# Altium schematic sheet CONNECTORS.SchDoc
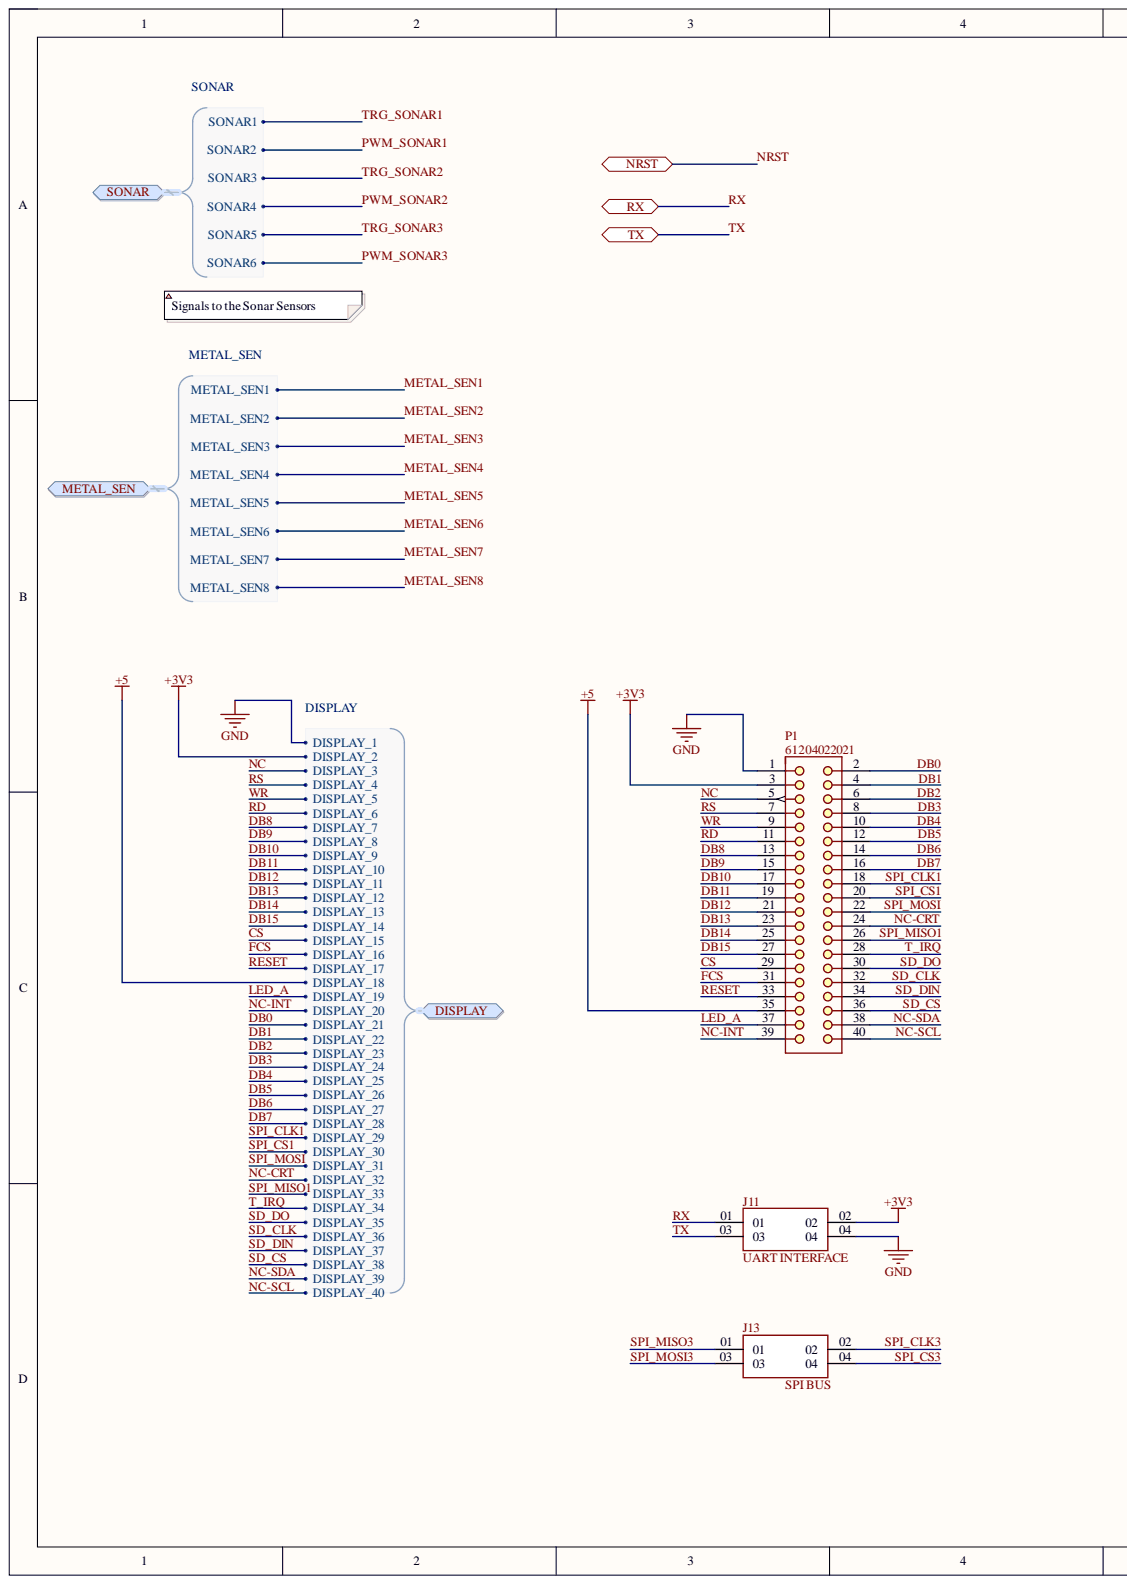
[diagram p0: CONNECTORS.SchDoc, left]
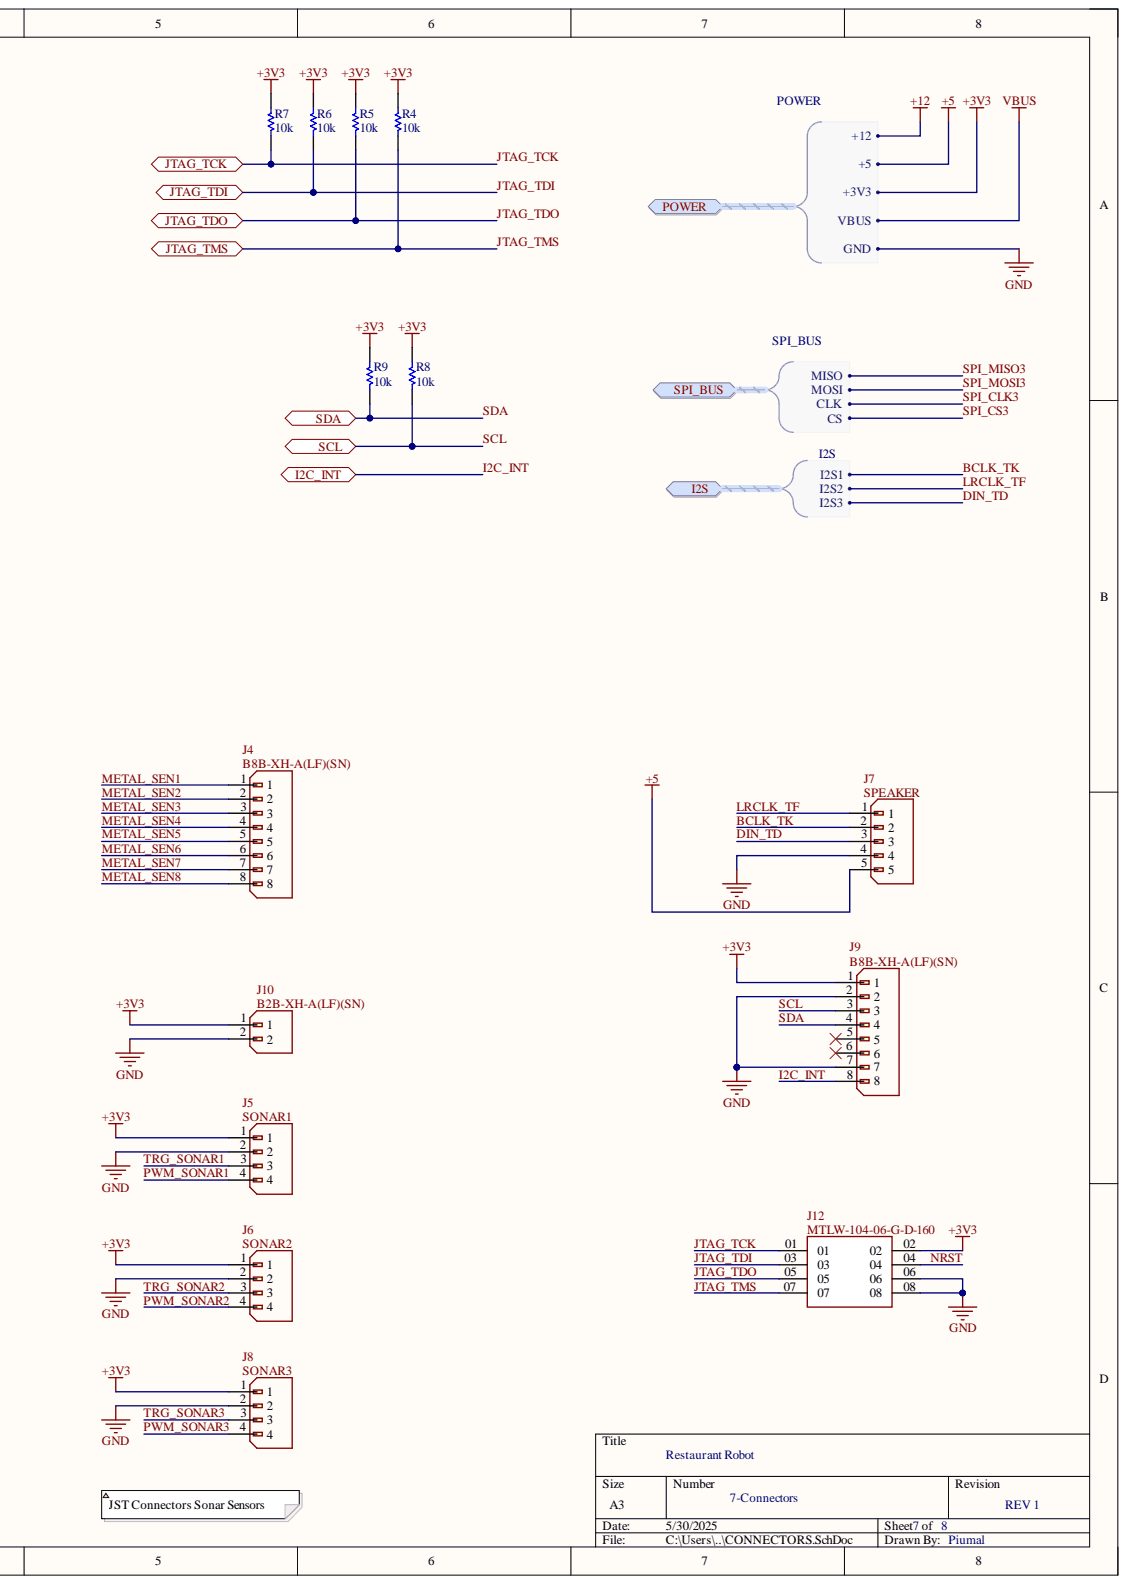
[diagram p1: CONNECTORS.SchDoc, right]

## Parts
J4  B8B-XH-A(LF)(SN)  [JST_B8B-XH-A(LF)(SN)]
J5  SONAR1  [JST_B4B-XH-A(LF)(SN)]
J6  SONAR2  [JST_B4B-XH-A(LF)(SN)]
J7  SPEAKER  [JST_B5B-XH-A(LF)(SN)]
J8  SONAR3  [JST_B4B-XH-A(LF)(SN)]
J9  B8B-XH-A(LF)(SN)  [JST_B8B-XH-A(LF)(SN)]
J10  B2B-XH-A(LF)(SN)  [JST_B2B-XH-A(LF)(SN)]
J11  UART INTERFACE  [SAMTEC_MTLW-102-XX-X-D-XXX]
J12  MTLW-104-06-G-D-160  [SAMTEC_MTLW-104-06-G-D-160]
J13  value SPI BUS  [SAMTEC_MTLW-102-XX-X-D-XXX]  (hidden comment: MTLW-102-XX-X-D-XXX)
P1  61204022021  [61204022021]
R4  Resistance: 10k  [RESC2013X60X35ML10T20]  (hidden comment: RC0805JR-0710KL)
R5  Resistance: 10k  [RESC2013X60X35ML10T20]  (hidden comment: RC0805JR-0710KL)
R6  Resistance: 10k  [RESC2013X60X35ML10T20]  (hidden comment: RC0805JR-0710KL)
R7  Resistance: 10k  [RESC2013X60X35ML10T20]  (hidden comment: RC0805JR-0710KL)
R8  Resistance: 10k  [RESC2013X60X35ML10T20]  (hidden comment: RC0805JR-0710KL)
R9  Resistance: 10k  [RESC2013X60X35ML10T20]  (hidden comment: RC0805JR-0710KL)

## Ports
DISPLAY, DISPLAY.DISPLAY_1, DISPLAY.DISPLAY_2, DISPLAY.DISPLAY_3, DISPLAY.DISPLAY_4, DISPLAY.DISPLAY_5, DISPLAY.DISPLAY_6, DISPLAY.DISPLAY_7, DISPLAY.DISPLAY_8, DISPLAY.DISPLAY_9, DISPLAY.DISPLAY_10, DISPLAY.DISPLAY_11, DISPLAY.DISPLAY_12, DISPLAY.DISPLAY_13, DISPLAY.DISPLAY_14, DISPLAY.DISPLAY_15, DISPLAY.DISPLAY_16, DISPLAY.DISPLAY_17, DISPLAY.DISPLAY_18, DISPLAY.DISPLAY_19, DISPLAY.DISPLAY_20, DISPLAY.DISPLAY_21, DISPLAY.DISPLAY_22, DISPLAY.DISPLAY_23, DISPLAY.DISPLAY_24, DISPLAY.DISPLAY_25, DISPLAY.DISPLAY_26, DISPLAY.DISPLAY_27, DISPLAY.DISPLAY_28, DISPLAY.DISPLAY_29, DISPLAY.DISPLAY_30, DISPLAY.DISPLAY_31, DISPLAY.DISPLAY_32, DISPLAY.DISPLAY_33, DISPLAY.DISPLAY_34, DISPLAY.DISPLAY_35, DISPLAY.DISPLAY_36, DISPLAY.DISPLAY_37, DISPLAY.DISPLAY_38, DISPLAY.DISPLAY_39, DISPLAY.DISPLAY_40, I2C_INT, I2S, I2S.I2S1, I2S.I2S2, I2S.I2S3, JTAG_TCK, JTAG_TDI, JTAG_TDO, JTAG_TMS, METAL_SEN, METAL_SEN.METAL_SEN1, METAL_SEN.METAL_SEN2, METAL_SEN.METAL_SEN3, METAL_SEN.METAL_SEN4, METAL_SEN.METAL_SEN5, METAL_SEN.METAL_SEN6, METAL_SEN.METAL_SEN7, METAL_SEN.METAL_SEN8, NRST, POWER, POWER.+3V3, POWER.+5, POWER.+12, POWER.GND, POWER.VBUS, RX, SCL, SDA, SONAR, SONAR.SONAR1, SONAR.SONAR2, SONAR.SONAR3, SONAR.SONAR4, SONAR.SONAR5, SONAR.SONAR6, SPI_BUS, SPI_BUS.CLK, SPI_BUS.CS, SPI_BUS.MISO, SPI_BUS.MOSI, TX

## Nets
+3V3: J5.1 J6.1 J8.1 J9.1 J10.1 J11.02 J12.02 P1.3 R4.2 R5.2 R6.2 R7.2 R8.2 R9.2 ; port DISPLAY, port POWER
+5: J7.5 P1.35 ; port DISPLAY, port POWER
BCLK_TK: J7.2 ; port I2S
CS: P1.29 ; port DISPLAY
DB0: P1.2 ; port DISPLAY
DB1: P1.4 ; port DISPLAY
DB2: P1.6 ; port DISPLAY
DB3: P1.8 ; port DISPLAY
DB4: P1.10 ; port DISPLAY
DB5: P1.12 ; port DISPLAY
DB6: P1.14 ; port DISPLAY
DB7: P1.16 ; port DISPLAY
DB8: P1.13 ; port DISPLAY
DB9: P1.15 ; port DISPLAY
DB10: P1.17 ; port DISPLAY
DB11: P1.19 ; port DISPLAY
DB12: P1.21 ; port DISPLAY
DB13: P1.23 ; port DISPLAY
DB14: P1.25 ; port DISPLAY
DB15: P1.27 ; port DISPLAY
DIN_TD: J7.3 ; port I2S
FCS: P1.31 ; port DISPLAY
GND: J5.2 J6.2 J7.4 J8.2 J9.2 J9.7 J10.2 J11.04 J12.06 J12.08 P1.1 ; port DISPLAY, port POWER
I2C_INT: J9.8 ; port I2C_INT
JTAG_TCK: J12.01 R7.1 ; port JTAG_TCK
JTAG_TDI: J12.03 R6.1 ; port JTAG_TDI
JTAG_TDO: J12.05 R5.1 ; port JTAG_TDO
JTAG_TMS: J12.07 R4.1 ; port JTAG_TMS
LED_A: P1.37 ; port DISPLAY
LRCLK_TF: J7.1 ; port I2S
METAL_SEN1: J4.1 ; port METAL_SEN
METAL_SEN2: J4.2 ; port METAL_SEN
METAL_SEN3: J4.3 ; port METAL_SEN
METAL_SEN4: J4.4 ; port METAL_SEN
METAL_SEN5: J4.5 ; port METAL_SEN
METAL_SEN6: J4.6 ; port METAL_SEN
METAL_SEN7: J4.7 ; port METAL_SEN
METAL_SEN8: J4.8 ; port METAL_SEN
NC: P1.5 ; port DISPLAY
NC-CRT: P1.24 ; port DISPLAY
NC-INT: P1.39 ; port DISPLAY
NC-SCL: P1.40 ; port DISPLAY
NC-SDA: P1.38 ; port DISPLAY
NRST: J12.04 ; port NRST
PWM_SONAR1: J5.4 ; port SONAR
PWM_SONAR2: J6.4 ; port SONAR
PWM_SONAR3: J8.4 ; port SONAR
RD: P1.11 ; port DISPLAY
RESET: P1.33 ; port DISPLAY
RS: P1.7 ; port DISPLAY
RX: J11.01 ; port RX
SCL: J9.3 R8.1 ; port SCL
SD_CLK: P1.32 ; port DISPLAY
SD_CS: P1.36 ; port DISPLAY
SD_DIN: P1.34 ; port DISPLAY
SD_DO: P1.30 ; port DISPLAY
SDA: J9.4 R9.1 ; port SDA
SPI_CLK1: P1.18 ; port DISPLAY
SPI_CLK3: J13.02 ; port SPI_BUS
SPI_CS1: P1.20 ; port DISPLAY
SPI_CS3: J13.04 ; port SPI_BUS
SPI_MISO1: P1.26 ; port DISPLAY
SPI_MISO3: J13.01 ; port SPI_BUS
SPI_MOSI: P1.22 ; port DISPLAY
SPI_MOSI3: J13.03 ; port SPI_BUS
T_IRQ: P1.28 ; port DISPLAY
TRG_SONAR1: J5.3 ; port SONAR
TRG_SONAR2: J6.3 ; port SONAR
TRG_SONAR3: J8.3 ; port SONAR
TX: J11.03 ; port TX
WR: P1.9 ; port DISPLAY
NetJ9_5: J9.5
NetJ9_6: J9.6
+12: ; port POWER
VBUS: ; port POWER

## Library data of the parts
J4 J9 = B8B-XH-A(LF)(SN): Connector Header Through Hole 8 position 0.098 (2.50mm); MF: JST Sales America Inc.; Check_prices: https://www.snapeda.com/parts/B8B-XH-A(LF)(SN)/JST+Sales+America+Inc./view-part/?ref=eda; Component Kind: Standard; Library Name: B8B-XH-A_LF__SN_ (1).IntLib; MP: B8B-XH-A(LF)(SN); Package: None; SnapEDA_Link: https://www.snapeda.com/parts/B8B-XH-A(LF)(SN)/JST+Sales+America+Inc./view-part/?ref=snap
J5 J6 J8 = B4B-XH-A(LF)(SN): Connector Header Through Hole 4 position 0.098 (2.50mm); MF: JST Sales; Check_prices: https://www.snapeda.com/parts/B4B-XH-A(LF)(SN)/JST+Sales+America+Inc./view-part/?ref=eda; Component Kind: Standard; Library Name: B4B-XH-A_LF__SN_.IntLib; MP: B4B-XH-A(LF)(SN); Package: None; SnapEDA_Link: https://www.snapeda.com/parts/B4B-XH-A(LF)(SN)/JST+Sales+America+Inc./view-part/?ref=snap
J7 = B5B-XH-A(LF)(SN): Connector Header Through Hole 5 position 0.098 (2.50mm); MF: JST Sales America Inc.; Check_prices: https://www.snapeda.com/parts/B5B-XH-A(LF)(SN)/JST+Sales+America+Inc./view-part/?ref=eda; Component Kind: Standard; Library Name: B5B-XH-A_LF__SN_.IntLib; MP: B5B-XH-A(LF)(SN); Package: None; SnapEDA_Link: https://www.snapeda.com/parts/B5B-XH-A(LF)(SN)/JST+Sales+America+Inc./view-part/?ref=snap
J10 = B2B-XH-A(LF)(SN): Connector Header Through Hole 2 position 0.098 (2.50mm); MF: JST Sales; Check_prices: https://www.snapeda.com/parts/B2B-XH-A(LF)(SN)/JST/view-part/?ref=eda; Component Kind: Standard; Library Name: B2B-XH-A_LF__SN_.IntLib; MP: B2B-XH-A(LF)(SN); Package: None; SnapEDA_Link: https://www.snapeda.com/parts/B2B-XH-A(LF)(SN)/JST/view-part/?ref=snap
J11 J13 = MTLW-102-XX-X-D-XXX: Connector Header Through Hole 4 position 0.100 (2.54mm); MF: Samtec; MP: MTLW-102-05-G-D-180; Check_prices: https://www.snapeda.com/parts/MTLW-102-05-G-D-180/Samtec/view-part/?ref=eda; Component Kind: Standard; Library Name: MTLW-102-XX-X-D-XXX.IntLib; Package: None; SnapEDA_Link: https://www.snapeda.com/parts/MTLW-102-05-G-D-180/Samtec/view-part/?ref=snap
P1 = CMP-1502-00074-2: NexarPartId: 32855492; Connector Type: Male Pin; Contact Resistance: 20mΩ; Current Rating: 3A; Gender: Male; Insulation Resistance: 1GΩ; Number of Contacts: 40; Operating Supply Voltage: 250V; Pitch: 2.54mm; Color: Black; Component Kind: Standard; Contact Material: Copper; Contact Mating Length: 5.6896mm; Contact Plating: Gold; Fastening Type: Latch; Insulation Material: PBT; Lead Pitch: 2.54mm; Length: 70.3mm; Library Name: Altium Content Vault; Max Operating Temperature: 105°C; Min Operating Temperature: -40°C; Mount: Through Hole; Number of Pins: 40; Number of Positions: 40; Number of Rows: 2; Orientation: Vertical; REACH SVHC: Unknown; RoHS: Compliant; Row Spacing: 2.54mm; Termination: Solder
R4-R9 = CMP-2000-05025-1: Chip Resistor, 10 KOhm, +/- 5%, 0.125 W, -55 to 155 degC, 0805 (2012 Metric), RoHS, Tape and Reel; Manufacturer: Yageo; Power Rating: 250mW; Product Range: RCSeries; Resistor Case Style: 0805[2012Metric]; Resistor Element Type: ThickFilm; SVHC: ToBeAdvised; Temperature Coefficient: ±100ppm/degC; Voltage Rating: 150V; Automotive Qualification Standard: -; Component Kind: Standard; Datasheet URL: http://www.yageo.ru/pdf/RC0805.pdf; Datasheet Version: REV. 2, 09/2004; Library Name: Altium Content Vault; Manufacturer URL: http://www.yageo.com/; MSL: MSL1-Unlimited; Package Description: 2-Pin Surface Mounted Device, Body 2 x 1.25 mm; Package Reference: 805; Packaging: CutTape; Resistance Tolerance: ±5%
J12 = MTLW-104-06-G-D-160: Availability: Unavailable; Component Kind: Standard; Library Name: MTLW-104-06-G-D-160.IntLib; MP: MTLW-104-06-G-D-160; Package: None; Price: None
